# Altium schematic sheet [1] PowerSupply.SchDoc("[1] PowerSupply")
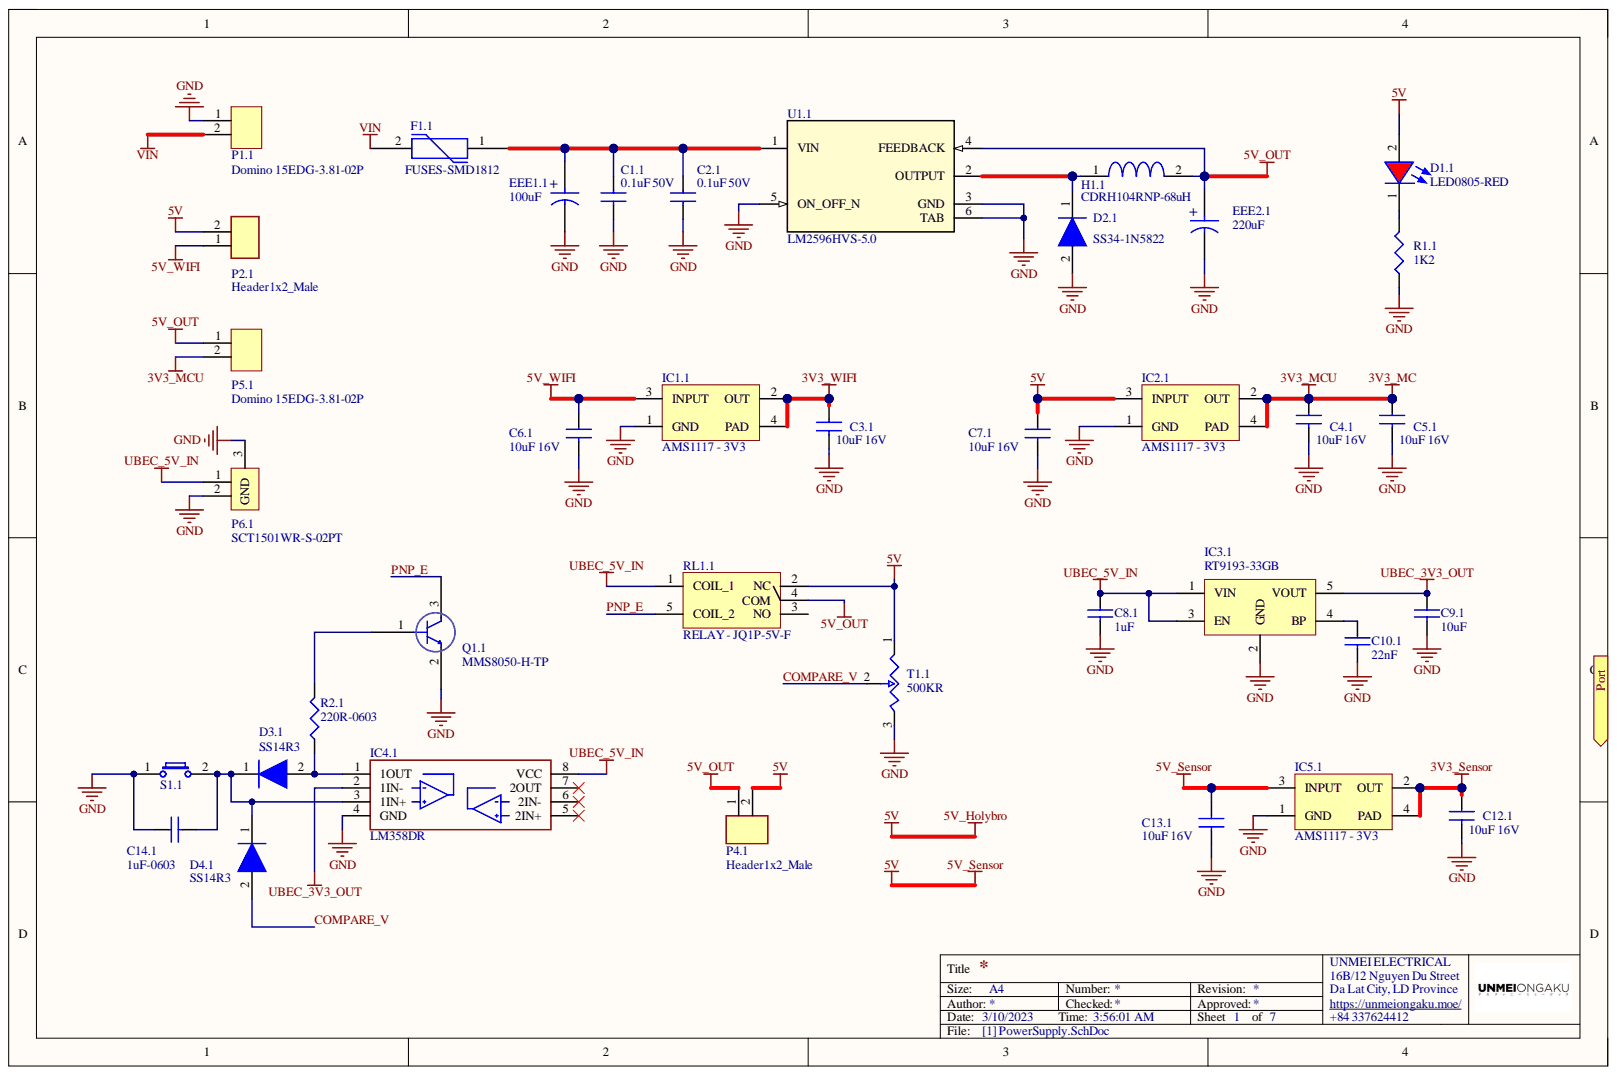
[diagram p0: [1] PowerSupply.SchDoc]

## Parts
C1.1  0.1uF 50V  [CAP0805]
C2.1  0.1uF 50V  Voltage Rating: 50V  [CAP0805]
C3.1  10uF 16V  Capacitance: 10uF  Voltage: 16V  [CAP0805]
C4.1  10uF 16V  Capacitance: 10uF  Voltage: 16V  [CAP0805]
C5.1  10uF 16V  Capacitance: 10uF  Voltage: 16V  [CAP0805]
C6.1  10uF 16V  Voltage: 16V  [CAP0805]
C7.1  10uF 16V  Voltage: 16V  [CAP0805]
C8.1  1uF  [CAP0603]
C9.1  10uF  [CAP0603]
C10.1  22nF  [CAP0603]
C12.1  10uF 16V  Capacitance: 10uF  Voltage: 16V  [CAP0805]
C13.1  10uF 16V  Voltage: 16V  [CAP0805]
C14.1  1uF-0603  [CAP0603]
D1.1  LED0805-RED  [LED0805-RED]
D2.1  SS34-1N5822  [SS34 - 1N5822 / DO-214AA, SMA]
D3.1  SS14R3  [SS14 - 1N5819 / DO-214AA, SMA]
D4.1  SS14R3  [SS14 - 1N5819 / DO-214AA, SMA]
EEE1.1  100uF  [CAPACITOR/ALU - SIZE D8/SMD - 6.3X7.7]
EEE2.1  220uF  [CAPACITOR/ALU - SIZE D8/SMD - 6.3X7.7]
F1.1  FUSES-SMD1812  [FUSES - SMD1812]
H1.1  CDRH104RNP-68uH  [CDRH104RNP]
IC1.1  AMS1117 - 3V3  [SOT223-4M_AMS1117_3V3]
IC2.1  AMS1117 - 3V3  [SOT223-4M_AMS1117_3V3]
IC3.1  RT9193-33GB  [SOT23-5]
IC4.1  LM358DR  [SOP8]
IC5.1  AMS1117 - 3V3  [SOT223-4M_AMS1117_3V3]
P1.1  Domino 15EDG-3.81-02P  [Domino 15EDG3.81 2P]
P2.1  Header1x2_Male  [HDR1x2_2.54_MALE]
P4.1  Header1x2_Male  [HDR1x2_2.54_MALE]
P5.1  Domino 15EDG-3.81-02P  [Domino 15EDG3.81 2P]
P6.1  SCT1501WR-S-02PT  [Connector ZH1.5 2P SMD]
Q1.1  MMS8050-H-TP  [SOT23]
R1.1  1K2  [RES0805]
R2.1  220R-0603  [RES0603 - 3D]
RL1.1  RELAY - JQ1P-5V-F  Manufacturer Part Number: JQ1P-5V-F  [RELAY - JQ1P - XV - F 10A SPDT]
S1.1  434121043816  [Switches-6x3.5x4.3mm]  (value not drawn)
T1.1  500KR  [Rheostat - 3296W]
U1.1  LM2596HVS-5.0  [TO265-5]

## Ports
Port

## Nets
3V3_MC: C4.1.1 C5.1.1 IC2.1.2(OUT) IC2.1.4(PAD) P5.1.2
3V3_Sensor: C12.1.1 IC5.1.2(OUT) IC5.1.4(PAD)
3V3_WIFI: C3.1.1 IC1.1.2(OUT) IC1.1.4(PAD)
5V: C7.1.1 C13.1.1 D1.1.2 IC2.1.3(INPUT) IC5.1.3(INPUT) P2.1.2 P4.1.2 RL1.1.2(NC) T1.1.1
5V_OUT: EEE2.1.1 H1.1.2 P4.1.1 P5.1.1 RL1.1.4(COM) U1.1.4(FEEDBACK)
5V_WIFI: C6.1.1 IC1.1.3(INPUT) P2.1.1
GND: C1.1.2 C2.1.2 C3.1.2 C4.1.2 C5.1.2 C6.1.2 C7.1.2 C8.1.2 C9.1.2 C10.1.2 C12.1.2 C13.1.2 C14.1.1 D2.1.2 EEE1.1.2 EEE2.1.2 IC1.1.1(GND) IC2.1.1(GND) IC3.1.2(GND) IC4.1.4(GND) IC5.1.1(GND) P1.1.1 P6.1.2 P6.1.3 Q1.1.2 R1.1.1 S1.1.1 T1.1.3 U1.1.3(GND) U1.1.5(ON_OFF_N) U1.1.6(TAB)
UBEC_3V3_OUT: C9.1.1 IC3.1.5(VOUT) IC4.1.2(1IN-)
UBEC_5V_IN: C8.1.1 IC3.1.1(VIN) IC3.1.3(EN) IC4.1.8(VCC) P6.1.1 RL1.1.1(COIL_1)
VIN: F1.1.2 P1.1.2
COMPARE_V: D4.1.2 T1.1.2
PNP_E: Q1.1.3 RL1.1.5(COIL_2)
NetC1.1_1: C1.1.1 C2.1.1 EEE1.1.1 F1.1.1 U1.1.1(VIN)
NetC10.1_1: C10.1.1 IC3.1.4(BP)
NetC14.1_2: C14.1.2 D3.1.1 D4.1.1 IC4.1.3(1IN+) S1.1.2
NetD1.1_1: D1.1.1 R1.1.2
NetD2.1_1: D2.1.1 H1.1.1 U1.1.2(OUTPUT)
NetD3.1_2: D3.1.2 IC4.1.1(1OUT) R2.1.1
NetQ1.1_1: Q1.1.1 R2.1.2
N00001: ; port Port

Not connected: IC4.1 5(2IN+) 6(2IN-) 7(2OUT); RL1.1 3(NO)

## Library data of the parts
C1.1 C2.1 = C0805C104K5RACTU: Cap Ceramic 0.1uF 50V X7R 10% SMD 2.0 x 1.2 mm 125 C Paper T/R; Manufacturer: KEMET; Capacitance: 100nF; Voltage: 500V; Case Code (Imperial): 0805; Case Code (Metric): 2012; Case/Package: 0805; Category: Ceramic Capacitors; Component Kind: Standard; ComponentLink1Description: https://datasheet.ciiva.com/32431/slceramic-chip-condensers-e-32431755.pdf?src-supplier=Distrelec; ComponentLink1URL: https://datasheet.ciiva.com/32431/slceramic-chip-condensers-e-32431755.pdf?src-supplier=Distrelec; ComponentLink2Description: https://datasheet.ciiva.com/28682/1851736-28682232.pdf?src-supplier=Element14; ComponentLink2URL: https://datasheet.ciiva.com/28682/1851736-28682232.pdf?src-supplier=Element14; ComponentLink3Description: https://datasheet.ciiva.com/18410/70095383-18410815.pdf?src-supplier=Allied+Electronics; ComponentLink3URL: https://datasheet.ciiva.com/18410/70095383-18410815.pdf?src-supplier=Allied+Electronics; ComponentLink4Description: https://datasheet.ciiva.com/14589/70095383-14589048.pdf?src-supplier=Allied+Electronics; ComponentLink4URL: https://datasheet.ciiva.com/14589/70095383-14589048.pdf?src-supplier=Allied+Electronics; Construction: Flat; Contact Plating: Tin,Matte; Depth: 1.25mm; Dielectric: X7R; Dielectric Material: Ceramic; Height: 0.9mm; Lead Pitch: 0.75mm; Length: 2mm; Manufacturer Part Number: C0805C104K5RACTU; Max Operating Temperature: 125°C; Min Operating Temperature: -55°C; Mount: Surface Mount,PCB; Number of Pins: 2; Package Quantity: 4000; Packaging: Tape and Reel; Pricing 1: 25=0.20300, 100=0.18800, 250=0.17500, 500=0.16400, 1250=0.15100 (USD); Radiation Hardening: No; REACH SVHC: No SVHC; RoHS: Yes; RoHS Compliant: Yes; Series: C0805C; Stock 1: 45175; Supplier 1: RSComponents; Supplier Part Number 1: 2644416; Termination: SMD/SMT; Thickness: 0.035inch; Tolerance: 10%; Voltage Rating (DC): 50V; Width: 1.2mm; Library Name: Minh_Lib.SchLib
  C1.1: Voltage Rating: 50V
C3.1 C4.1 C5.1 C6.1 C7.1 C12.1 C13.1 = C0805C106K4PACTU: Ceramic Capacitors MLCC - SMD/SMT 16V 10uF X5R 0805 10%; Manufacturer: KEMET; Dissipation Factor: 5%; Case Code (Imperial): 0805; Case Code (Metric): 2012; Case/Package: 0805; Category: Ceramic Capacitors; Component Kind: Standard; ComponentLink1Description: https://datasheet.ciiva.com/7842/1732713-7842196.pdf?src-supplier=Element14; ComponentLink1URL: https://datasheet.ciiva.com/7842/1732713-7842196.pdf?src-supplier=Element14; ComponentLink2Description: https://datasheet.ciiva.com/28682/1831760-28682305.pdf?src-supplier=Element14; ComponentLink2URL: https://datasheet.ciiva.com/28682/1831760-28682305.pdf?src-supplier=Element14; ComponentLink3Description: https://datasheet.ciiva.com/11787/0900766b8116eb59-11787314.pdf?src-supplier=RSComponents; ComponentLink3URL: https://datasheet.ciiva.com/11787/0900766b8116eb59-11787314.pdf?src-supplier=RSComponents; ComponentLink4Description: https://datasheet.ciiva.com/7842/1732713-7842197.pdf?src-supplier=Element14; ComponentLink4URL: https://datasheet.ciiva.com/7842/1732713-7842197.pdf?src-supplier=Element14; ComponentLink5Description: https://datasheet.ciiva.com/26847/getdatasheetpartid-110990-26847815.pdf?src-supplier=Verical; ComponentLink5URL: https://datasheet.ciiva.com/26847/getdatasheetpartid-110990-26847815.pdf?src-supplier=Verical; Construction: Flat; Contact Plating: Tin,Matte; Depth: 1.25mm; Dielectric: X5R; Dielectric Material: Ceramic; Height: 1.25mm; Lead Free: Lead Free; Length: 2mm; Manufacturer Part Number: C0805C106K4PACTU; Max Operating Temperature: 85°C; Min Operating Temperature: -55°C; Mount: Surface Mount; Number of Pins: 2; Package Quantity: 1; Packaging: Tape and Reel; Pricing 1: 10=1.00600, 50=0.94500, 100=0.88500, 250=0.82400, 500=0.75400 (USD); Radiation Hardening: No; REACH SVHC: No SVHC; RoHS: Yes; RoHS Compliant: Yes; Series: C; Stock 1: 60; Supplier 1: RSComponents; Supplier Part Number 1: 6911161; Termination: SMD/SMT; Thickness: 0.055inch; Tolerance: 10%; Voltage Rating: 16V; Voltage Rating (DC): 16V; Width: 0.049inch; Library Name: Minh_Lib.SchLib
  C6.1 C7.1 C13.1: Capacitance: 10uF
C8.1 C14.1 = CC0603KRX7R7BB105: CAP CER 1UF 16V X7R 0603; Manufacturer 1: Yageo; Capacitance: 1µF; Category 1: Capacitors; Tolerance: ±10%; Applications: General Purpose; Component Kind: Standard; ComponentLink1Description: http://www.yageo.com/documents/recent/UPY-GPHC_X7R_6.3V-to-50V_18.pdf; ComponentLink1URL: http://www.yageo.com/documents/recent/UPY-GPHC_X7R_6.3V-to-50V_18.pdf; Description 1: CAP CER 1UF 16V X7R 0603; Failure Rate: -; Features: -; Height - Seated (Max): -; Lead Spacing: -; Lead Style: -; Manufacturer Part Number 1: CC0603KRX7R7BB105; Mounting Type: Surface Mount, MLCC; Operating Temperature: -55°C ~ 125°C; Package / Case: 0603 (1608 Metric); Packaging: Cut Tape (CT); Part Status: Active; Pricing 1: 1=0.1, 10=0.051, 100=0.0227, 500=0.0162, 1000=0.01274 (USD); Ratings: -; RoHS 1: RoHS Compliant; Size / Dimension: 0.063" L x 0.031" W (1.60mm x 0.80mm); Stock 1: 274580; Supplier 1: Digi-Key; Supplier Part Number 1: 311-1446-1-ND; Temperature Coefficient: X7R; Thickness (Max): 0.035" (0.90mm); Value: 1uF; Voltage - Rated: 16V; Library Name: Minh_Lib.SchLib
C9.1 = CC0603KRX5R6BB106: CAP CER 10UF 10V X5R 0603; Manufacturer 1: Yageo; Capacitance: 10µF; Category 1: Capacitors; Tolerance: ±10%; Applications: General Purpose; Component Kind: Standard; ComponentLink1Description: http://www.yageo.com/documents/recent/UPY-GPHC_X5R_4V-to-50V_24.pdf; ComponentLink1URL: http://www.yageo.com/documents/recent/UPY-GPHC_X5R_4V-to-50V_24.pdf; Description 1: CAP CER 10UF 10V X5R 0603; Failure Rate: -; Features: -; Height - Seated (Max): -; Lead Spacing: -; Lead Style: -; Manufacturer Part Number 1: CC0603KRX5R6BB106; Mounting Type: Surface Mount, MLCC; Operating Temperature: -55°C ~ 85°C; Package / Case: 0603 (1608 Metric); Packaging: Cut Tape (CT); Part Status: Active; Pricing 1: 1=0.33, 10=0.225, 100=0.1272, 500=0.08982, 1000=0.07858 (USD); Ratings: -; RoHS 1: RoHS Compliant; Size / Dimension: 0.063" L x 0.031" W (1.60mm x 0.80mm); Stock 1: 66566; Supplier 1: Digi-Key; Supplier Part Number 1: 311-1782-1-ND; Temperature Coefficient: X5R; Thickness (Max): 0.035" (0.90mm); Value: 10uF; Voltage - Rated: 10V; Library Name: Minh_Lib.SchLib
C10.1 = CC0603KRX7R9BB223: Multilayer Ceramic Capacitors MLCC - SMD/SMT 22nF 50V X7R 10%; Brand: Yageo; Capacitance: 0.022 uF; Capacitance - pF: 22000 pF; Class: Class 2; Type: General Purpose and High Capacitance Surface Mount Ceramic Multilayer Capacitor; Voltage Rating DC: 50 VDC; Capacitance - nF: 22 nF; Case Code - in: 0603; Case Code - mm: 1608; Category: Multilayer Ceramic Capacitors MLCC - SMD/SMT; Component Kind: Standard; ComponentLink1Description: https://www.mouser.com/datasheet/2/447/UPY-GPHC_X7R_6.3V-to-50V_18-1154002.pdf; ComponentLink1URL: https://www.mouser.com/datasheet/2/447/UPY-GPHC_X7R_6.3V-to-50V_18-1154002.pdf; Dielectric: X7R; Height: 0.8 mm; Length: 1.6 mm; Manufacturer: Yageo; Manufacturer Part Number: CC0603KRX7R9BB223; Maximum Operating Temperature: + 125 C; Minimum Operating Temperature: - 55 C; Minimum Order Quantity: 1; Package / Case: 0603 (1608 metric); Packaging: Cut Tape; Pricing 1: 1=0.100, 10=0.064, 100=0.020, 1000=0.015, 4000=0.011, 8000=0.010, 24000=0.009 (USD); Product: General Type MLCCs; Product Type: Ceramic Capacitors; Reeling: True; RoHS: RoHS Compliant; RoHS - Mouser: Y; Series: CC; Standard Pack Qty: 4000; Stock 1: 23033; Subcategory: Capacitors; Supplier 1: Mouser; Supplier Part Number 1: 603-CC603KRX7R9BB223; Termination: Standard; Termination Style: SMD/SMT; Tolerance: 10 %; Value: 22nF; Width: 0.8 mm; Library Name: Minh_Lib.SchLib
D2.1 = SS34: SS Series 500 mV 3 A 40 V Max Reverse Voltage Schottky Rectifier - DO-214AB; Manufacturer: ON Semiconductor / Fairchild; Average Rectified Current: 3A; Diode Element Material: SILICON; Element Configuration: Single; Forward Voltage: 500mV; Max Forward Surge Current (Ifsm): 100A; Max Power Dissipation: 2.27W; Max Repetitive Reverse Voltage (Vrrm): 40V; Max Reverse Current: 500uA; Number of Elements: 1; Number of Terminals/Pins: 2; Package Body Material: Plastic; Polarity: Standard; Case/Package: SMC; Category: Schottky Diodes; Component Kind: Standard; Contact Plating: Tin,Matte; Current: 3A; Current Rating: 3A; Forward Current: 3A; Height: 2.75mm; JEDEC-95 Code: DO-214AB; JESD-30 Code: R-PDSO-C2; Lead Free: Lead Free; Length: 7.15mm; Manufacturer Part Number: SS34; Max Operating Temperature: 150°C; Max Reverse Leakage Current: 500uA; Max Reverse Voltage (DC): 40V; Max Surge Current: 100A; Min Operating Temperature: -55°C; Moisture Sensitivity Level: 1; Mount: Surface Mount; Number of Phases: 1; Number of Pins: 2; Output Current: 3A; Package Quantity: 1; Package Shape: Rectangular; Packaging: Tape and Reel; Peak Non-Repetitive Surge Current: 100A; Peak Reflow Temperature (Cel): 260°C; Pricing 1: 1=0.65000, 25=0.57600, 50=0.50900, 100=0.44100, 250=0.39500, 500=0.34900, 1000=0.27900 (USD); Radiation Hardening: No; Reach SVHC Compliant: Yes; RoHS: Yes; RoHS Compliant: Yes; Series: -; Stock 1: 7040; Supplier 1: Newark; Supplier Part Number 1: 18C8659; Surface Mount: Yes; Technology: SCHOTTKY; Terminal Finish: Tin; Terminal Form: C BEND; Terminal Position: DUAL; Voltage: 40V; Voltage Rating (DC): 40V; Width: 6.25mm; Library Name: Minh_Lib.SchLib
D3.1 D4.1 = SS14R3: 1A, 40V, Schottky Rectifier; Manufacturer: Taiwan Semiconductor; Case/Package: SMA; Category: Uncategorized; Component Kind: Standard; ComponentLink1Description: http://components.arrow.com/part/dataview/0323_01151906?src-supplier=Arrow+Europe; ComponentLink1URL: http://components.arrow.com/part/dataview/0323_01151906?src-supplier=Arrow+Europe; Manufacturer Part Number: SS14R3; Number of Pins: 2; Pricing 1: 3600=0.07700, 9000=0.06800, 10000=0.06800, 23400=0.06400, 48600=0.05800 (USD); RoHS: Yes; Stock 1: 5400; Supplier 1: Mouser; Supplier Part Number 1: 821-SS14R3; Library Name: Minh_Lib.SchLib
EEE1.1 EEE2.1 = EEE-1EA101XP: Cap Aluminum 100uF 25V 20% (6.3 X 7.7mm) SMD 143mA 2000h 85°C T/R; Manufacturer: Panasonic; Leakage Current: 3uA; Life (Hours): 2000Hour; Polarity: Polar; Capacitance: 100uF; Case/Package: Radial; Category: Aluminum Electrolytic Capacitors; Component Kind: Standard; ComponentLink1Description: https://datasheet.ciiva.com/24022/aba0000ce2-40801-24022875.pdf?src-supplier=Mouser; ComponentLink1URL: https://datasheet.ciiva.com/24022/aba0000ce2-40801-24022875.pdf?src-supplier=Mouser; ComponentLink2Description: https://datasheet.ciiva.com/16201/aba0000ce2-16201065.pdf?src-supplier=Future+Electronics; ComponentLink2URL: https://datasheet.ciiva.com/16201/aba0000ce2-16201065.pdf?src-supplier=Future+Electronics; ComponentLink3Description: https://datasheet.ciiva.com/30108/11aba0000ce2-30108047.pdf?src-supplier=Onlinecomponents.com; ComponentLink3URL: https://datasheet.ciiva.com/30108/11aba0000ce2-30108047.pdf?src-supplier=Onlinecomponents.com; ComponentLink4Description: https://datasheet.ciiva.com/17529/aba0000ce2-17529557.pdf?src-supplier=Avnet+Europe; ComponentLink4URL: https://datasheet.ciiva.com/17529/aba0000ce2-17529557.pdf?src-supplier=Avnet+Europe; Construction: Cylindrical; Contact Plating: Tin; Diameter: 6.3mm; Height: 7.7mm; Height - Seated (Max): 0.303inch; Lead Free: Lead Free; Length: 8mm; Manufacturer Part Number: EEE-1EA101XP; Max Operating Temperature: 85°C; Min Operating Temperature: -40°C; Mount: Surface Mount,PCB; Package Quantity: 900; Packaging: Tape and Reel; Pricing 1: 1=0.52000, 10=0.36100, 50=0.25240, 100=0.21630 (USD); Radiation Hardening: No; REACH SVHC: Unknown; Ripple Current: 143mA; Ripple Current (AC): 143mA; RoHS: Yes; RoHS Compliant: Yes; Series: S; Supplier 1: Digi-Key; Supplier Part Number 1: PCE3898CT-ND; Termination: SMD/SMT; Tolerance: 20%; Voltage: 25V; Voltage Rating: 25V; Voltage Rating (DC): 25V; Library Name: Minh_Lib.SchLib
H1.1 = CDRH104RNP-680NC: Ind Power Shielded Wirewound 68uH 30% 100KHz Ferrite 1.42A Carrier T/R; Manufacturer: Sumida; DC Resistance (DCR): 213mR; Rated Current-Max: 1.5A; Case/Package: Nonstandard; Category: Fixed Inductors; Component Kind: Standard; ComponentLink1Description: http://www.sumida.com/products/pdf/CDRH104,104R.pdf?src-supplier=Digi-Key; ComponentLink1URL: http://www.sumida.com/products/pdf/CDRH104,104R.pdf?src-supplier=Digi-Key; ComponentLink2Description: http://products.sumida.com/products/pdf/CDRH104R.pdf?src-supplier=Digi-Key; ComponentLink2URL: http://products.sumida.com/products/pdf/CDRH104R.pdf?src-supplier=Digi-Key; ComponentLink3Description: http://www.sumida.com/products/pdf/CDRH104,104R.pdf?src-supplier=Mouser; ComponentLink3URL: http://www.sumida.com/products/pdf/CDRH104,104R.pdf?src-supplier=Mouser; ComponentLink4Description: http://www.sumida.com/products/pdf/CDRH104R.pdf?src-supplier=Avnet; ComponentLink4URL: http://www.sumida.com/products/pdf/CDRH104R.pdf?src-supplier=Avnet; ComponentLink5Description: http://www.sumida.com/products/pdf/CDRH104,104R.pdf?src-supplier=Future+Electronics; ComponentLink5URL: http://www.sumida.com/products/pdf/CDRH104,104R.pdf?src-supplier=Future+Electronics; Core Material: Ferrite; Current Rating: 1.42A; Depth: 10.3mm; Height: 4mm; Height - Seated (Max): 0.157inch; Inductance: 68uH; Lead Free: Lead Free; Length: 0.402inch; Manufacturer Part Number: CDRH104RNP-680NC; Max DC Current: 1.42A; Max Operating Temperature: 100°C; Min Operating Temperature: -40°C; Mount: Surface Mount; Package Quantity: 1000; Packaging: Tape and Reel; Pricing 1: 1=1.78000, 10=1.64700, 25=1.51000, 50=1.29060, 100=1.15320, 250=0.98848, 500=0.93356 (USD); Radiation Hardening: No; RoHS: Yes; RoHS Compliant: Yes; Saturation Current: 1.5A; Series: CDRH; Shielding: Shielded; Stock 1: 26557; Supplier 1: Digi-Key; Supplier Part Number 1: 308-2170-1-ND; Termination: SMD/SMT; Test Frequency: 100kHz; Tolerance: 30%; Width: 0.405inch; Library Name: Minh_Lib.SchLib
IC1.1 IC2.1 IC5.1 = AMS1117 - 3V3: LDO Voltage Regulators 3.3V 1A Positive; Manufacturer Part Number: NCP1117ST33T3G; Brand: ON Semiconductor; Dropout Voltage: 0.95 V at 100 mA; Dropout Voltage - Max: 1.1 V at 100 mA; Ib - Input Bias Current: 6 mA; Input Voltage MAX: 20 V; Line Regulation: 0.8 mV; Load Regulation: 4.3 mV; Number of Outputs: 1 Output; Output Type: Fixed; Quiescent Current: 10 mA; Voltage Regulation Accuracy: 1 %; Category: LDO Voltage Regulators; Component Kind: Standard; ComponentLink1Description: https://www.mouser.com/ds/2/308/NCP1117-D-81326.pdf; ComponentLink1URL: https://www.mouser.com/ds/2/308/NCP1117-D-81326.pdf; Height: 1.69 mm (Max); Length: 6.7 mm (Max); Manufacturer: ON Semiconductor; Maximum Operating Temperature: + 125 C; Minimum Operating Temperature: 0 C; Minimum Order Quantity: 1; Mounting Style: SMD/SMT; Output Current: 1 A; Output Voltage: 3.3 V; Package / Case: SOT-223-3; Packaging: Cut Tape; Polarity: Positive; Pricing 1: 1=0.450, 10=0.366, 100=0.223, 1000=0.173, 4000=0.147, 8000=0.137, 24000=0.130, 48000=0.127 (USD); Reeling: True; RoHS: RoHS Compliant; RoHS - Mouser: Y; Series: NCP1117; Standard Pack Qty: 4000; Stock 1: 0; Supplier 1: Mouser; Supplier Part Number 1: 863-NCP1117ST33T3G; Width: 3.7 mm (Max); Library Name: Minh_Lib.SchLib
IC3.1 = RT9193-33GB: IC REG LDO 3.3V 0.3A SOT23-5; Component Kind: Standard; Library Name: Minh_Lib.SchLib
IC4.1 = LM358DR: IC OPAMP GP 700KHZ 8SOIC; Manufacturer: Texas Instruments; Amplifier Type: GENERAL PURPOSE; Common Mode Rejection Ratio: 65dB; Dual Supply Voltage: 9V; Input Bias Current: 250nA; Input Offset Voltage (Vos): 7mV; Max Dual Supply Voltage: 16V; Max Supply Voltage: 32V; Min Dual Supply Voltage: 1.5V; Min Supply Voltage: 3V; Nominal Supply Current: 1.2mA; Number of Amplifiers: 2; Operating Supply Current: 1mA; Output Current: 30mA; Slew Rate: 0.3V/µs; Voltage Gain: 100dB; Bandwidth: 700kHz; Case/Package: SOIC; Category: Amplifiers - Op Amps, Buffer, Instrumentation; Component Kind: Standard; ComponentLink1Description: https://datasheet.ciiva.com/1795/lm358-1795488.pdf?src-supplier=Digi-Key; ComponentLink1URL: https://datasheet.ciiva.com/1795/lm358-1795488.pdf?src-supplier=Digi-Key; ComponentLink2Description: https://datasheet.ciiva.com/27056/2016330-27056228.pdf?src-supplier=Jameco; ComponentLink2URL: https://datasheet.ciiva.com/27056/2016330-27056228.pdf?src-supplier=Jameco; ComponentLink3Description: https://datasheet.ciiva.com/7709/1874566-7709823.pdf?src-supplier=Element14; ComponentLink3URL: https://datasheet.ciiva.com/7709/1874566-7709823.pdf?src-supplier=Element14; ComponentLink4Description: https://datasheet.ciiva.com/46385/1874566-46385851.pdf?src-supplier=Element14; ComponentLink4URL: https://datasheet.ciiva.com/46385/1874566-46385851.pdf?src-supplier=Element14; ComponentLink5Description: https://datasheet.ciiva.com/46390/1874566-46390414.pdf?src-supplier=Element14; ComponentLink5URL: https://datasheet.ciiva.com/46390/1874566-46390414.pdf?src-supplier=Element14; ComponentLink6Description: https://datasheet.ciiva.com/1795/lm358-1795487.pdf?src-supplier=Digi-Key; ComponentLink6URL: https://datasheet.ciiva.com/1795/lm358-1795487.pdf?src-supplier=Digi-Key; ComponentLink7Description: https://datasheet.ciiva.com/1795/lm358-1795489.pdf?src-supplier=Digi-Key; ComponentLink7URL: https://datasheet.ciiva.com/1795/lm358-1795489.pdf?src-supplier=Digi-Key; ComponentLink8Description: https://datasheet.ciiva.com/2973/lm358a-2973386.pdf?src-supplier=Avnet; ComponentLink8URL: https://datasheet.ciiva.com/2973/lm358a-2973386.pdf?src-supplier=Avnet; Contact Plating: Gold; Gain Bandwidth Product: 700kHz; Height: 1.58mm; Lead Free: Lead Free; Length: 4.9mm; Manufacturer Part Number: LM358DR; Max Operating Temperature: 70°C; Min Operating Temperature: 0°C; Mount: Surface Mount; Number of Channels: 2; Number of Circuits: 2; Number of Elements: 2; Number of Pins: 8; Operating Supply Voltage: 16V; Output Current per Channel: 30mA; Package Quantity: 2500; Packaging: Tape and Reel; Power Supply Rejection Ratio (PSRR): 65dB; Pricing 1: 1=0.39310, 10=0.31690, 25=0.28850, 100=0.21440, 250=0.19280, 500=0.19100, 1000=0.15350 (USD); Radiation Hardening: No; Reach SVHC Compliant: Yes; RoHS: Yes; RoHS Compliant: Yes; Series: -; Stock 1: 1847; Supplier 1: Arrow Electronics; Supplier Part Number 1: LM358DR; Supply Current: 1.2mA; Technology: BIPOLAR; Unity Gain Bandwidth Product: 700kHz; Width: 3.91mm; Library Name: Minh_Lib.SchLib
P2.1 P4.1 = HDR1x2_2.54_MALE: Header1x2_2.54_MALE; Component Kind: Standard; Library Name: Minh_Lib.SchLib
Q1.1 = MMS8050-H-TP: Trans Gp Bjt NPN 25V 0.5A 3-PIN SOT-23 T/r; Manufacturer: MCC; Collector Emitter Voltage (VCEO): 600mV; Max Collector Current: 500mA; Max Power Dissipation: 300mW; Transition Frequency: 150MHz; Case/Package: TO-236-3; Category: Uncategorized; Collector Emitter Breakdown Voltage: 25V; Component Kind: Standard; ComponentLink1Description: http://components.arrow.com/part/dataview/56206496S302966N2022?src-supplier=Arrow+Electronics; ComponentLink1URL: http://components.arrow.com/part/dataview/56206496S302966N2022?src-supplier=Arrow+Electronics; ComponentLink2Description: http://www.mccsemi.com/up_pdf/MMS8050(SOT-23).pdf?src-supplier=Digi-Key; ComponentLink2URL: http://www.mccsemi.com/up_pdf/MMS8050(SOT-23).pdf?src-supplier=Digi-Key; Manufacturer Part Number: MMS8050-H-TP; Max Operating Temperature: 150°C; Min Operating Temperature: -55°C; Mount: Surface Mount; Number of Pins: 3; Packaging: Tape and Reel; Pricing 1: 1=0.21000, 10=0.17100, 50=0.17100, 100=0.09100, 1000=0.04100, 10000=0.02700 (USD); RoHS: Yes; RoHS Compliant: Yes; Stock 1: 417; Supplier 1: Mouser; Supplier Part Number 1: 833-MMS8050-H-TP; Library Name: Minh_Lib.SchLib
R1.1 = RC0805JR-071K5L: 贴片电阻 1.5KΩ ±5% 1/8W ±100ppm/℃ 0805; Manufacturer: Yageo; Max Power Dissipation: 125mW; Resistance: 1.5kR; Temperature Coefficient: 100ppm/°C; Voltage Rating: 150V; Case Code (Imperial): 0805; Case Code (Metric): 2012; Case/Package: 0805; Category: Chip SMD Resistors; Component Kind: Standard; ComponentLink1Description: https://datasheet.ciiva.com/46395/444164-46395130.pdf?src-supplier=Element14; ComponentLink1URL: https://datasheet.ciiva.com/46395/444164-46395130.pdf?src-supplier=Element14; ComponentLink2Description: https://datasheet.ciiva.com/2456/pyu-r-int-thick-7-2456074.pdf?src-supplier=Digi-Key; ComponentLink2URL: https://datasheet.ciiva.com/2456/pyu-r-int-thick-7-2456074.pdf?src-supplier=Digi-Key; ComponentLink3Description: https://datasheet.ciiva.com/2456/pyu-r-int-thick-7-2456075.pdf?src-supplier=Digi-Key; ComponentLink3URL: https://datasheet.ciiva.com/2456/pyu-r-int-thick-7-2456075.pdf?src-supplier=Digi-Key; ComponentLink4Description: https://datasheet.ciiva.com/2456/pyu-r-int-thick-7-2456073.pdf?src-supplier=Digi-Key; ComponentLink4URL: https://datasheet.ciiva.com/2456/pyu-r-int-thick-7-2456073.pdf?src-supplier=Digi-Key; ComponentLink5Description: https://datasheet.ciiva.com/17026/rc0805-17026842.pdf?src-supplier=Future+Electronics; ComponentLink5URL: https://datasheet.ciiva.com/17026/rc0805-17026842.pdf?src-supplier=Future+Electronics; Construction: Rectangular; Contact Plating: Tin,Matte,Lead; Depth: 1.25mm; Features: Moisture Resistant; Height: 0.024inch; Lead Free: Lead Free; Length: 0.079inch; Manufacturer Part Number: RC0805JR-071K5L; Max Operating Temperature: 155°C; Military Standard: Not; Min Operating Temperature: -55°C; Number of Terminations: 2; Package Quantity: 1; Packaging: Tape and Reel; Power Rating: 125mW; Pricing 1: 1=0.12000, 25=0.06000, 50=0.03800, 100=0.02700, 250=0.02100, 500=0.01600, 1000=0.01100, 2500=0.00900 (USD); Radiation Hardening: No; REACH SVHC: Unknown; Resistance Tolerance: 5%; Resistor Type: Thick Film; RoHS: Yes; RoHS Compliant: Yes; Series: RC; Size Code: 0805; Stock 1: 2129; Supplier 1: Newark; Supplier Part Number 1: 68R0255; Termination: SMD/SMT; Tolerance: 5%; Width: 0.049inch; Library Name: Minh_Lib.SchLib
R2.1 = RC0603JR-07220RL: RES SMD 220 OHM 5% 1/10W 0603; Manufacturer 1: Yageo; Category 1: Resistors; Power (Watts): 0.1W, 1/10W; Resistance (Ohms): 220; Temperature Coefficient: ±100ppm/°C; Value: 220R; Component Kind: Standard; ComponentLink1Description: http://www.yageo.com/documents/recent/PYu-RC_Group_51_RoHS_L_7.pdf; ComponentLink1URL: http://www.yageo.com/documents/recent/PYu-RC_Group_51_RoHS_L_7.pdf; Composition: Thick Film; Description 1: RES SMD 220 OHM 5% 1/10W 0603; Failure Rate: -; Features: Moisture Resistant; Height - Seated (Max): 0.022" (0.55mm); Manufacturer Part Number 1: RC0603JR-07220RL; Operating Temperature: -55°C ~ 155°C; Package / Case: 0603 (1608 Metric); Packaging: Cut Tape (CT); Part Status: Active; Pricing 1: 1=0.1, 10=0.011, 100=0.0044, 1000=0.00198, 2500=0.00172 (USD); RoHS 1: RoHS Compliant; Size / Dimension: 0.063" L x 0.031" W (1.60mm x 0.80mm); Stock 1: 1346821; Supplier 1: Digi-Key; Supplier Device Package: 0603; Supplier Part Number 1: 311-220GRCT-ND; Tolerance: ±5%; Library Name: Minh_Lib.SchLib
RL1.1 = RELAY - JQ1P-5V-F: RELAY GEN PURPOSE SPDT 10A 5V; Manufacturer: Panasonic; Coil Current: 80mA; Coil Power: 400mW; Coil Resistance: 62.5R; Coil Type: Non-Latching; Max Voltage Rating (AC): 250V; Max Voltage Rating (DC): 110V; Operate Time: 20ms; Relay Type: General Purpose; Release Time: 10ms; Category: Signal Relays; Coil Voltage (DC): 5V; Component Kind: Standard; ComponentLink1Description: https://datasheet.ciiva.com/30096/mech-eng-jq-30096783.pdf?src-supplier=Onlinecomponents.com; ComponentLink1URL: https://datasheet.ciiva.com/30096/mech-eng-jq-30096783.pdf?src-supplier=Onlinecomponents.com; ComponentLink2Description: https://datasheet.ciiva.com/8000/1643500-8000170.pdf?src-supplier=Element14; ComponentLink2URL: https://datasheet.ciiva.com/8000/1643500-8000170.pdf?src-supplier=Element14; Contact Current Rating: 10A; Contact Material: Tin,Silver; Depth: 10mm; Height: 15.6mm; Lead Free: Lead Free; Length: 20mm; Max Operating Temperature: 70°C; Min Operating Temperature: -40°C; Mount: Through Hole; Number of Pins: 5; Package Quantity: 100; Packaging: Bulk; Power Consumption: 400mW; Power Rating: 400mW; Pricing 1: 1=2.43000, 10=2.26000, 25=2.01000, 50=1.92000, 100=1.84000 (GBP); RoHS: Yes; RoHS Compliant: Yes; Series: JQ; Supplier 1: Farnell; Supplier Part Number 1: 2095667; Termination: PC Pin; Throw Configuration: SPDT; Voltage Rating (AC): 250V; Weight: 7g; Width: 20mm; Library Name: Minh_Lib.SchLib
S1.1 = 434121043816: Switch Tactile Spst-no 0.05A 12V; Manufacturer: Wurth Electronics; Actuator Length: 0.8mm; Actuator Material: Polymer,Liquid Crystal Polymer; Contact Current Rating: 50mA; Illumination Type: Non-Illuminated,Illuminated; Insulation Resistance: 100MR; Mechanical Life: 50000Cycles; Operating Force: 160gf; Switch Function: Off-Mom; Switch Travel: 0.25mm; Throw Configuration: SPST; Category: Tactile Switches; Component Kind: Standard; ComponentLink1Description: https://datasheet.ciiva.com/30466/0900766b8126b631-30466746.pdf?src-supplier=RSComponents; ComponentLink1URL: https://datasheet.ciiva.com/30466/0900766b8126b631-30466746.pdf?src-supplier=RSComponents; ComponentLink2Description: https://datasheet.ciiva.com/29123/711754-da-01-en-taster-434121043816-29123819.pdf?src-supplier=Conrad; ComponentLink2URL: https://datasheet.ciiva.com/29123/711754-da-01-en-taster-434121043816-29123819.pdf?src-supplier=Conrad; ComponentLink3Description: https://datasheet.ciiva.com/1532/434121043816-1532524.pdf?src-supplier=Element14; ComponentLink3URL: https://datasheet.ciiva.com/1532/434121043816-1532524.pdf?src-supplier=Element14; ComponentLink4Description: https://datasheet.ciiva.com/1633/434121043816-1633934.pdf?src-supplier=Farnell; ComponentLink4URL: https://datasheet.ciiva.com/1633/434121043816-1633934.pdf?src-supplier=Farnell; Contact Material: Stainless Steel,Steel; Contact Type: 1 maker; Current Rating: 50mA; Height: 4.3mm; Length: 8mm; Manufacturer Part Number: 434121043816; Max Operating Temperature: 85°C; Min Operating Temperature: -40°C; Mount: Surface Mount; Packaging: Tape and Reel; Pricing 1: 1=1.69500 (USD); REACH SVHC: No SVHC; RoHS: Yes; RoHS Compliant: Yes; Series: WS-TASV; Stock 1: 337; Supplier 1: RSComponents; Supplier Part Number 1: 7856421; Termination: SMD/SMT; Width: 3.5mm; Library Name: Minh_Lib.SchLib
T1.1 = 3296W-1-504LF: Res Cermet Trimmer 500K Ohm 10% 1/2W 25(Elec)Turn 2.19mm (9.53 X 4.83 X 11.55mm) Pin Thru-Hole Tube; Manufacturer: Bourns; Color: Blue; Max Power Dissipation: 500mW; Number of Turns: 25; Temperature Coefficient: 100ppm/°C; Category: Variable Resistors and Potentiometers; Component Kind: Standard; ComponentLink1Description: https://datasheet.ciiva.com/11832/0900766b8069ccf4-11832237.pdf?src-supplier=RSComponents; ComponentLink1URL: https://datasheet.ciiva.com/11832/0900766b8069ccf4-11832237.pdf?src-supplier=RSComponents; ComponentLink2Description: https://datasheet.ciiva.com/19466/853812-19466623.pdf?src-supplier=Jameco; ComponentLink2URL: https://datasheet.ciiva.com/19466/853812-19466623.pdf?src-supplier=Jameco; ComponentLink3Description: https://datasheet.ciiva.com/29122/447286-da-01-en-trimmer-tht-3296w-1-504lf-500k-0-5w-29122370.pdf?src-supplier=Conrad; ComponentLink3URL: https://datasheet.ciiva.com/29122/447286-da-01-en-trimmer-tht-3296w-1-504lf-500k-0-5w-29122370.pdf?src-supplier=Conrad; ComponentLink4Description: https://datasheet.ciiva.com/3266/3296-3266198.pdf?src-supplier=Avnet; ComponentLink4URL: https://datasheet.ciiva.com/3266/3296-3266198.pdf?src-supplier=Avnet; ComponentLink5Description: https://datasheet.ciiva.com/15156/getdatasheetpartid-27989-15156235.pdf?src-supplier=Verical; ComponentLink5URL: https://datasheet.ciiva.com/15156/getdatasheetpartid-27989-15156235.pdf?src-supplier=Verical; ComponentLink6Description: https://datasheet.ciiva.com/2156/3296-2156894.pdf?src-supplier=Digi-Key; ComponentLink6URL: https://datasheet.ciiva.com/2156/3296-2156894.pdf?src-supplier=Digi-Key; ComponentLink7Description: https://datasheet.ciiva.com/30196/3296-30196060.pdf?src-supplier=Onlinecomponents.com; ComponentLink7URL: https://datasheet.ciiva.com/30196/3296-30196060.pdf?src-supplier=Onlinecomponents.com; ComponentLink8Description: https://datasheet.ciiva.com/16715/70153578-16715020.pdf?src-supplier=Allied+Electronics; ComponentLink8URL: https://datasheet.ciiva.com/16715/70153578-16715020.pdf?src-supplier=Allied+Electronics; ComponentLink9Description: https://datasheet.ciiva.com/1131/1815117-1131347.pdf?src-supplier=Element14; ComponentLink9URL: https://datasheet.ciiva.com/1131/1815117-1131347.pdf?src-supplier=Element14; Contact Plating: Tin,Matte; Depth: 4.83mm; Height: 11.55mm; Lead Free: Lead Free; Length: 9.53mm; Manufacturer Part Number: 3296W-1-504LF; Max Operating Temperature: 125°C; Military Standard: Not; Min Operating Temperature: -55°C; Mount: Through Hole,Panel,PCB; Package Quantity: 50; Packaging: Rail/Tube; Power Rating: 500mW; Pricing 1: 1=2.43000, 10=1.85000, 25=1.82000, 50=1.79000, 100=1.76000, 500=1.39000 (USD); Radiation Hardening: No; Reach SVHC Compliant: Yes; Resistance: 500kR; Resistance Tolerance: 10%; Resistor Type: Cermet; RoHS: Yes; RoHS Compliant: Yes; Series: 3296; Stock 1: 95; Supplier 1: Newark; Supplier Part Number 1: 62J1477; Taper: Linear; Technology: CERMET; Termination: Pin; Tolerance: 10%; Weight: 0.03oz; Width: 9.53mm; Library Name: Minh_Lib.SchLib
U1.1 = LM2596S-ADJ/NOPB: Optoisolator 5KV Trans 4SMD / Optocoupler Dc-in 1-CH Transistor Dc-out 4-PIN PDIP SMD; Manufacturer: Texas Instruments; Manufacturer Part Number: PC817XNNIP0F; PartNumber: LM2596SX-ADJ/NOPB; Collector Emitter Saturation Voltage: 200mV; Collector Emitter Voltage (VCEO): 80V; CompType: IC; Fall Time: 18000ns; Forward Current: 50mA; Forward Voltage: 1.4V; Input Type: DC; Max Power Dissipation: 200mW; Number of Channels: 1; Output Current per Channel: 30mA; Reverse Breakdown Voltage: 6V; Alternate_PartNumber: LM2596S-ADJ/NOPB; Case/Package: SMD/SMT; Category: Optocouplers; Collector-emitter Voltage-Max: 200mV; Component Kind: Standard; ComponentLink1Description: https://datasheet.ciiva.com/15119/getdatasheetpartid-299374-15119023.pdf?src-supplier=Verical; ComponentLink1URL: https://datasheet.ciiva.com/15119/getdatasheetpartid-299374-15119023.pdf?src-supplier=Verical; ComponentLink2Description: https://datasheet.ciiva.com/2086/pc817xxnip0f-series-2086508.pdf?src-supplier=Digi-Key; ComponentLink2URL: https://datasheet.ciiva.com/2086/pc817xxnip0f-series-2086508.pdf?src-supplier=Digi-Key; ComponentLink3Description: https://datasheet.ciiva.com/2086/pc817xxnip0f-series-2086507.pdf?src-supplier=Digi-Key; ComponentLink3URL: https://datasheet.ciiva.com/2086/pc817xxnip0f-series-2086507.pdf?src-supplier=Digi-Key; ComponentLink4Description: https://datasheet.ciiva.com/2086/pc817xxnip0f-series-2086509.pdf?src-supplier=Digi-Key; ComponentLink4URL: https://datasheet.ciiva.com/2086/pc817xxnip0f-series-2086509.pdf?src-supplier=Digi-Key; ComponentLink5Description: https://datasheet.ciiva.com/46396/1812378-46396954.pdf?src-supplier=Element14; ComponentLink5URL: https://datasheet.ciiva.com/46396/1812378-46396954.pdf?src-supplier=Element14; ComponentLink6Description: https://datasheet.ciiva.com/46435/1812378-46435723.pdf?src-supplier=Element14; ComponentLink6URL: https://datasheet.ciiva.com/46435/1812378-46435723.pdf?src-supplier=Element14; ComponentLink7Description: https://datasheet.ciiva.com/16475/pc817xnnip0f-16475911.pdf?src-supplier=Future+Electronics; ComponentLink7URL: https://datasheet.ciiva.com/16475/pc817xnnip0f-16475911.pdf?src-supplier=Future+Electronics; Contact Plating: Copper,Tin; Input Current: 50mA; Isolation Voltage: 5kV; Isolation Voltage-Max: 5kV; Lead Free: Lead Free; Manufacturer_Part_Number: LM2596S-ADJ/NOPB; Max Collector Current: 50mA; Max Input Current: 50mA; Max Operating Temperature: 100°C; Max Output Voltage: 80V; Mfr_Name: Texas Instruments; Min Operating Temperature: -30°C; Mount: Surface Mount; MountType: SMT; Number of Elements: 1; Number of Pins: 4; Output Voltage: 80V; Package Quantity: 2000; PackageReference: KTT0005B; Packaging: Tape and Reel; Power Dissipation: 200mW; Pricing 1: 1=0.31700, 25=0.29900, 100=0.21300, 250=0.17700, 500=0.14100, 2000=0.13800 (GBP); Radiation Hardening: No; RefDes: RefDes; Rise Time: 18000ns; RoHS: Y; RoHS Compliant: Yes; Supplier 1: Farnell; Supplier Part Number 1: 2420057; Type: DEV; Copyright: Copyright (C) 2021 Ultra Librarian. All rights reserved.; Library Name: Minh_Lib.SchLib
D1.1 = LED0805-RED: Component Kind: Standard; Library Name: Minh_Lib.SchLib
F1.1 = FUSES-SMD1812: Component Kind: Standard; Library Name: Minh_Lib.SchLib
P1.1 P5.1 = Domino 15EDG-3.81-02P: Component Kind: Standard; Library Name: Minh_Lib.SchLib
P6.1 = SCT1501WR-S-02PT: Component Kind: Standard; Library Name: Minh_Lib.SchLib
